# Altium schematic sheet AD630平衡调制解调模块.SchDoc
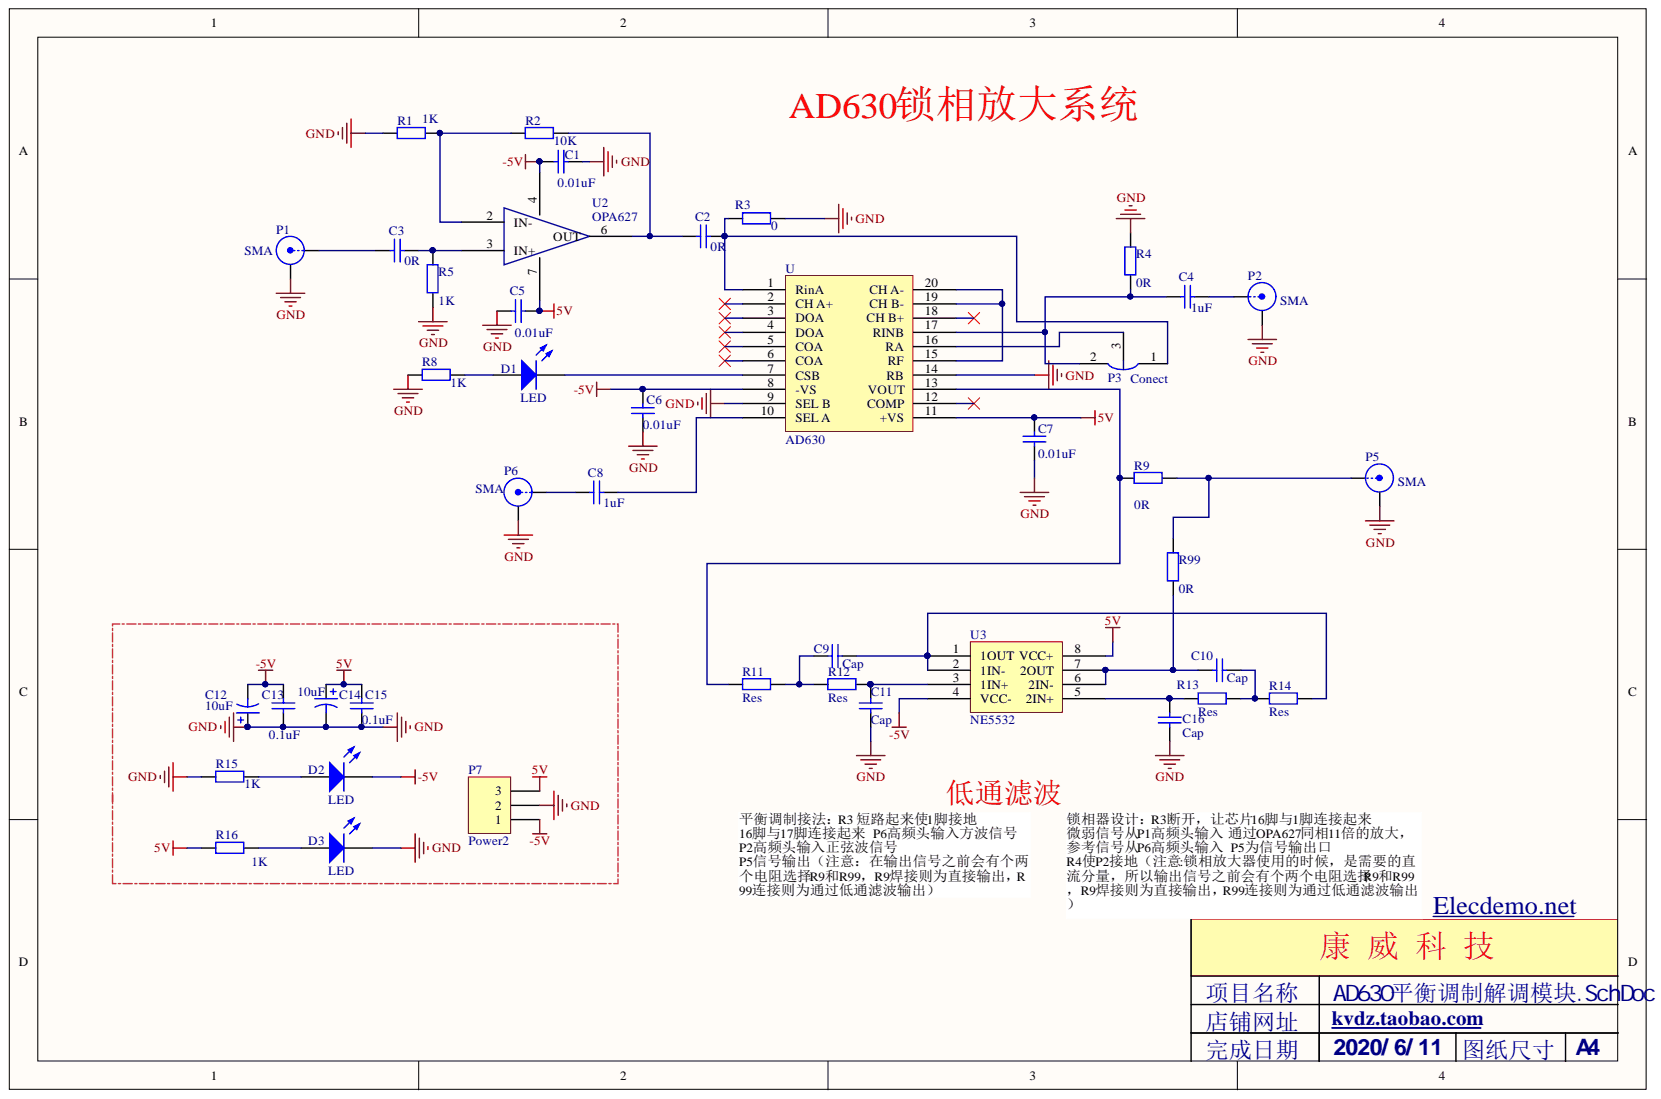
[diagram p0: AD630平衡调制解调模块.SchDoc]

## Parts
C1  value 0.01uF  [C0805]
C2  value 0R  [C0805]
C3  value 0R  [C0805]
C4  value 1uF  [C0805]
C5  value 0.01uF  [C0805]
C6  value 0.01uF  [C0805]
C7  value 0.01uF  [C0805]
C8  value 1uF  [C0805]
C9  Cap  [C0805]
C10  Cap  [C0805]
C11  Cap  [C0805]
C12  value 10uF  [C3528]
C13  value 0.1uF  [C0805]
C14  value 10uF  [C3528]
C15  value 0.1uF  [C0805]
C16  Cap  [C0805]
D1  LED  [LED0805R]
D2  LED  [LED0805R]
D3  LED  [LED0805R]
P1  SMA  [SMA]
P2  SMA  [SMA]
P3  Conect  [connect1]
P5  SMA  [SMA]
P6  SMA  [SMA]
P7  Power2  [3PIN-5.08B]
R1  value 1K  [R0805]
R2  value 10K  [R0805]
R3  value 0  [R0805]
R4  value 0R  [R0805]
R5  value 1K  [R0805]
R8  value 1K  [R0805]
R9  value 0R  [R0805]
R11  Res  [R0805]
R12  Res  [R0805]
R13  Res  [R0805]
R14  Res  [R0805]
R15  value 1K  [R0805]
R16  value 1K  [R0805]
R99  value 0R  [R0805]
U  AD630  [DIP20]
U2  OPA627  [SOP8]
U3  NE5532  [SOP8]

## Nets
5V: C5.2 C7.2 C14.1 C15.2 P7.3 R16.1 U.11(+VS) U2.7 U3.8(VCC+)
-5V: C1.2 C6.2 C12.2 C13.1 D2.2 P7.1 U.8(-VS) U2.4 U3.4(VCC-)
GND: C1.1 C5.1 C6.1 C7.1 C11.1 C12.1 C13.2 C14.2 C15.1 C16.2 D3.2 P1.2 P2.2 P5.2 P6.2 P7.2 R1.1 R3.2 R4.2 R5.1 R8.1 R15.1 U.9(SEL_B) U.14(RB)
NetC2_1: C2.1 P3.1 R3.1 U.1(RinA)
NetC2_2: C2.2 R2.2 U2.6(OUT)
NetC3_1: C3.1 R5.2 U2.3(IN+)
NetC3_2: C3.2 P1.1
NetC4_1: C4.1 P2.1
NetC4_2: C4.2 P3.2 R4.1 U.17(RINB)
NetC8_1: C8.1 U.10(SEL_A)
NetC8_2: C8.2 P6.1
NetC9_1: C9.1 R14.2 U3.1(1OUT) U3.2(1IN-)
NetC9_2: C9.2 R11.2 R12.1
NetC10_1: C10.1 R13.2 R14.1
NetC10_2: C10.2 R99.2 U3.6(2IN-) U3.7(2OUT)
NetC11_2: C11.2 R12.2 U3.3(1IN+)
NetC16_1: C16.1 R13.1 U3.5(2IN+)
NetD1_1: D1.1 R8.2
NetD1_2: D1.2 U.7(CSB)
NetD2_1: D2.1 R15.2
NetD3_1: D3.1 R16.2
NetP3_3: P3.3 U.16(RA)
NetP5_1: P5.1 R9.1 R99.1
NetR1_2: R1.2 R2.1 U2.2(IN-)
NetR9_2: R9.2 R11.1 U.13(VOUT)
NetU_15: U.15(RF) U.19(CH_B-) U.20(CH_A-)

Not connected: U 2(CH_A+) 3(DOA) 4(DOA) 5(COA) 6(COA) 12(COMP) 18(CH_B+)

## Library data of the parts
C1 = Cap: Capacitor; Value: 0.01uF; Component Kind: Standard; LatestRevisionDate: 17-Jul-2002; LatestRevisionNote: Re-released for DXP Platform.; Library Name: Miscellaneous Devices.IntLib; PackageDescription: Radial Cap, Thru-Hole; 2 Leads; 0.3 in Pin Spacing; PackageReference: RAD-0.3; Published: 8-Jun-2000; Signal Integrity: Cap; Simulation: CAP; Publisher: Altium Limited
C2-C11 C13 C15 C16 = Cap: Capacitor; Component Kind: Standard; LatestRevisionDate: 17-Jul-2002; LatestRevisionNote: Re-released for DXP Platform.; PackageDescription: Radial Cap, Thru-Hole; 2 Leads; 0.3 in Pin Spacing; PackageReference: RAD-0.3; Published: 8-Jun-2000; Signal Integrity: Cap; Simulation: CAP; Publisher: Altium Limited
  C2-C8 C13 C15: Library Name: Miscellaneous Devices.IntLib
  C9-C11 C16: Library Name: Xiaowei.SchLib
C12 C14 = Cap Pol1: Polarized Capacitor (Radial); Component Kind: Standard; LatestRevisionDate: 17-Jul-2002; LatestRevisionNote: Re-released for DXP Platform.; Library Name: Miscellaneous Devices.IntLib; PackageDescription: Polarized Capacitor (Radial); 2 Leads; PackageReference: RB7.6-15; Published: 8-Jun-2000; Signal Integrity: Cap Pol; Simulation: CAP; Publisher: Altium Limited
P1 P2 P5 P6 = BNC: BNC Elbow Connector; Component Kind: Standard; LatestRevisionDate: 17-Jul-2002; LatestRevisionNote: Re-released for DXP Platform.; Library Name: Miscellaneous Devices.SchLib; Signal Integrity: Connector; Publisher: Altium Limited
P3 = 连接口: Component Kind: Standard; Library Name: Xiaowei.SchLib
P7 = Header 3: Header, 3-Pin; Component Kind: Standard; LatestRevisionDate: 17-Jul-2002; LatestRevisionNote: Re-released for DXP Platform.; Signal Integrity: Connector; Library Name: Xiaowei.SchLib; Publisher: Altium Limited
R1-R5 R8 R9 R15 R16 R99 = Res2: Resistor; Component Kind: Standard; LatestRevisionDate: 17-Jul-2002; LatestRevisionNote: Re-released for DXP Platform.; Library Name: Miscellaneous Devices.IntLib; PackageDescription: Axial Device, Thru-Hole; 2 Leads; 0.4 in Pin Spacing; PackageReference: AXIAL-0.4; Published: 8-Jun-2000; Signal Integrity: Res; Simulation: RESISTOR; Publisher: Altium Limited
R11-R14 = Res: Resistor; Value: 1K; Component Kind: Standard; LatestRevisionDate: 17-Jul-2002; LatestRevisionNote: Re-released for DXP Platform.; PackageDescription: Axial Device, Thru-Hole; 2 Leads; 0.4 in Pin Spacing; PackageReference: AXIAL-0.4; Published: 8-Jun-2000; Signal Integrity: Res; Simulation: RESISTOR; Library Name: Xiaowei.SchLib; Publisher: Altium Limited
U2 = OP07: Component Kind: Standard; Library Name: Xiaowei.SchLib
D1-D3 = LED: Component Kind: Standard; LatestRevisionDate: 08-Jul-2005; LatestRevisionNote: Mech Layer 1 removed.; PackageDescription: LED; 2 Leads; PackageReference: LED-0; Published: 8-Jun-2000; Library Name: Xiaowei.SchLib; Publisher: Altium Limited
U = AD630: Component Kind: Standard; Library Name: KWKJ.SchLib
U3 = NE5532: Component Kind: Standard; Library Name: Xiaowei.SchLib
